# Altium schematic sheet offset.SchDoc
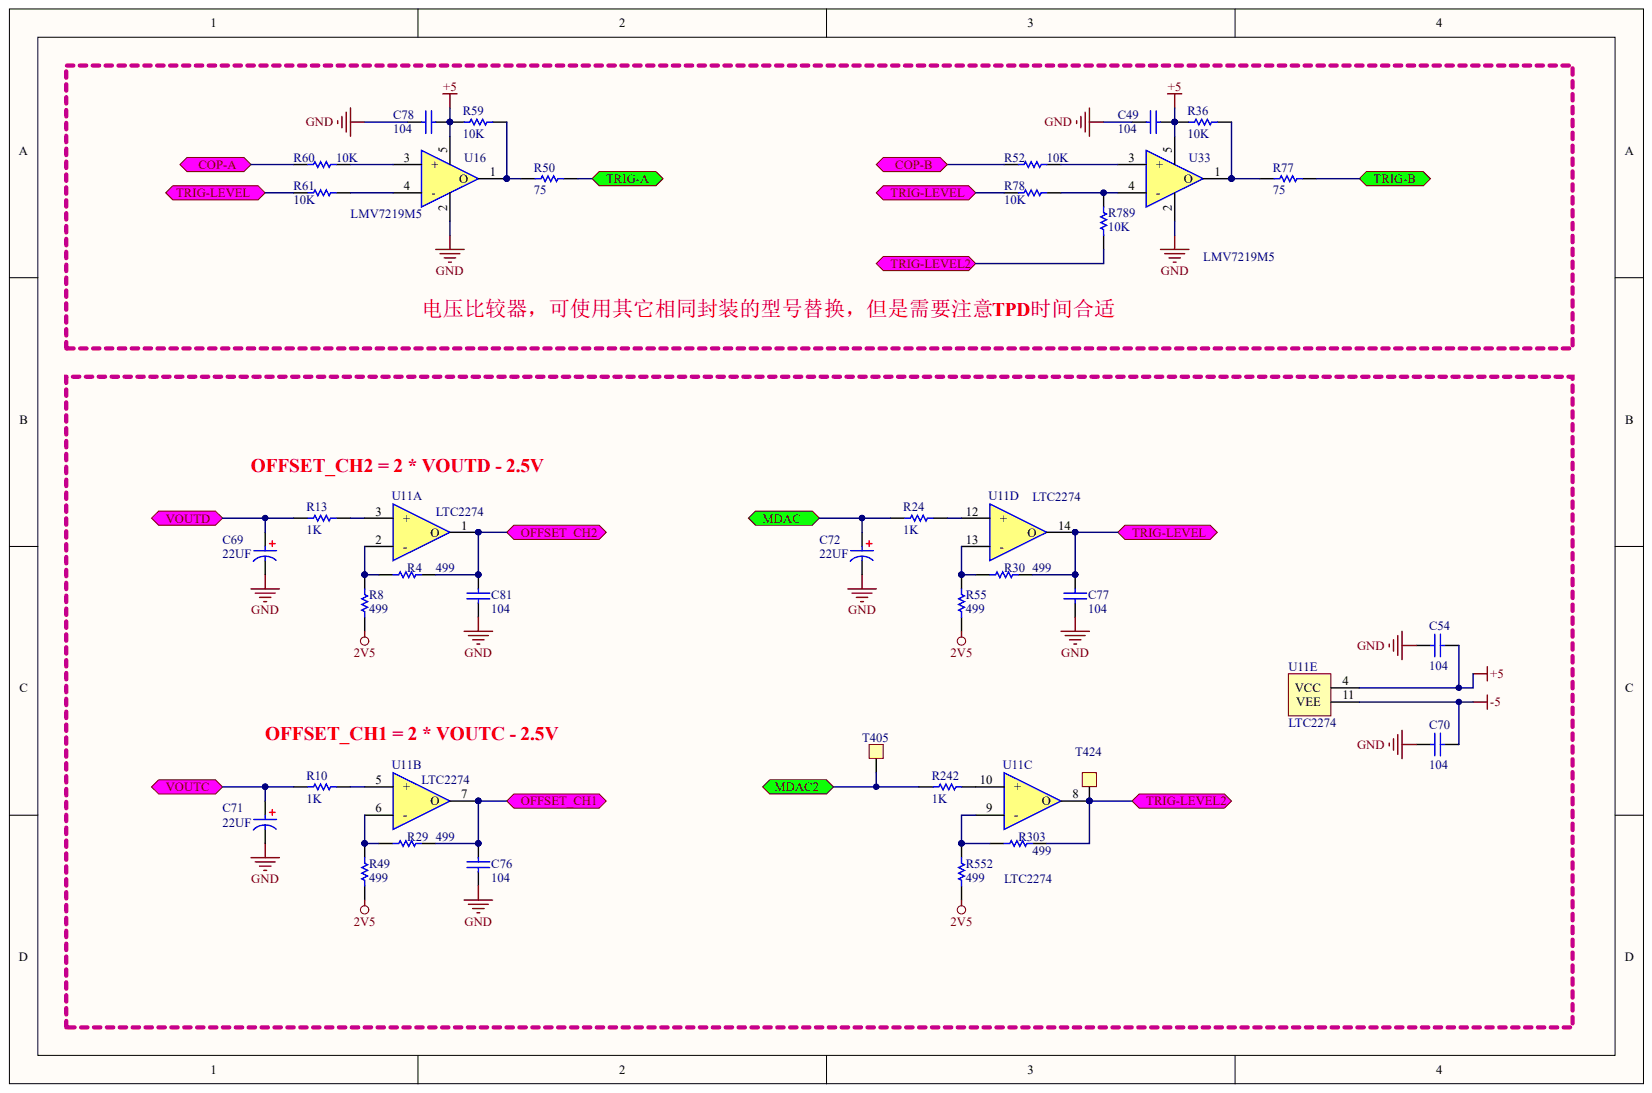
[diagram p0: offset.SchDoc]

## Parts
C49  C104  (value not drawn)
C54  C104  (value not drawn)
C69  22UF
C70  C104  (value not drawn)
C71  22UF
C72  22UF
C76  C104  (value not drawn)
C77  C104  (value not drawn)
C78  C104  (value not drawn)
C81  C104  (value not drawn)
R4  499
R8  499
R10  1K
R13  1K
R24  1K
R29  499
R30  499
R36  10K
R49  499
R50  75
R52  10K
R55  499
R59  10K
R60  10K
R61  10K
R77  75
R78  10K
R242  1K
R303  499
R552  499
R789  10K
T405  TP  (value not drawn)
T424  TP  (value not drawn)
U11  LTC2274  (parts A,B,C,D,E)
U16  LMV7219M5
U33  LMV7219M5

## Ports
COP-A, COP-B, MDAC, MDAC2, OFFSET_CH1, OFFSET_CH2, TRIG-A, TRIG-B, TRIG-LEVEL, TRIG-LEVEL2, VOUTC, VOUTD

## Nets
2V5: R8.1 R49.1 R55.1 R552.1
+5: C49.1 C54.1 C78.1 R36.1 R59.1 U11.4(VCC) U16.5 U33.5
-5: C70.1 U11.11(VEE)
GND: C49.2 C54.2 C69.2 C70.2 C71.2 C72.2 C76.2 C77.2 C78.2 C81.2 U16.2 U33.2
COP-A: R60.1 ; port COP-A
COP-B: R52.1 ; port COP-B
MDAC: C72.1 R24.1 ; port MDAC
MDAC2: R242.1 T405.1 ; port MDAC2
OFFSET_CH1: C76.1 R29.2 U11.7(O) ; port OFFSET_CH1
OFFSET_CH2: C81.1 R4.2 U11.1(O) ; port OFFSET_CH2
TRIG-A: R50.1 ; port TRIG-A
TRIG-B: R77.1 ; port TRIG-B
TRIG-LEVEL: C77.1 R30.2 R61.1 R78.1 U11.14(O) ; port TRIG-LEVEL
TRIG-LEVEL2: R303.2 R789.1 T424.1 U11.8(O) ; port TRIG-LEVEL2
VOUTC: C71.1 R10.1 ; port VOUTC
VOUTD: C69.1 R13.1 ; port VOUTD
NetR4_1: R4.1 R8.2 U11.2(-)
NetR10_2: R10.2 U11.5(+)
NetR13_2: R13.2 U11.3(+)
NetR24_2: R24.2 U11.12(+)
NetR29_1: R29.1 R49.2 U11.6(-)
NetR30_1: R30.1 R55.2 U11.13(-)
NetR36_2: R36.2 R77.2 U33.1(O)
NetR50_2: R50.2 R59.2 U16.1(O)
NetR52_2: R52.2 U33.3(+)
NetR60_2: R60.2 U16.3(+)
NetR61_2: R61.2 U16.4(-)
NetR78_2: R78.2 R789.2 U33.4(-)
NetR242_2: R242.2 U11.10(+)
NetR303_1: R303.1 R552.2 U11.9(-)

## Library data of the parts
C49 C54 C69-C72 C76-C78 C81 R4 R8 R10 R13 R24 R29 R30 R36 R49 R50 R52 R55 R59-R61 R77 R78 R242 R303 R552 R789 T405 T424 U11 U16 U33 = (no symbol name)
  C49 C54 C70 C76-C78 C81: : 104
  C69 C71 C72: : 22UF
  R4 R8 R29 R30 R49 R55 R303 R552: : 499
  R10 R13 R24 R242: : 1K
  R36 R52 R59-R61 R78 R789: : 10K
  R50 R77: : 75
